# Altium schematic sheet 01-ece353_carrier.SchDoc
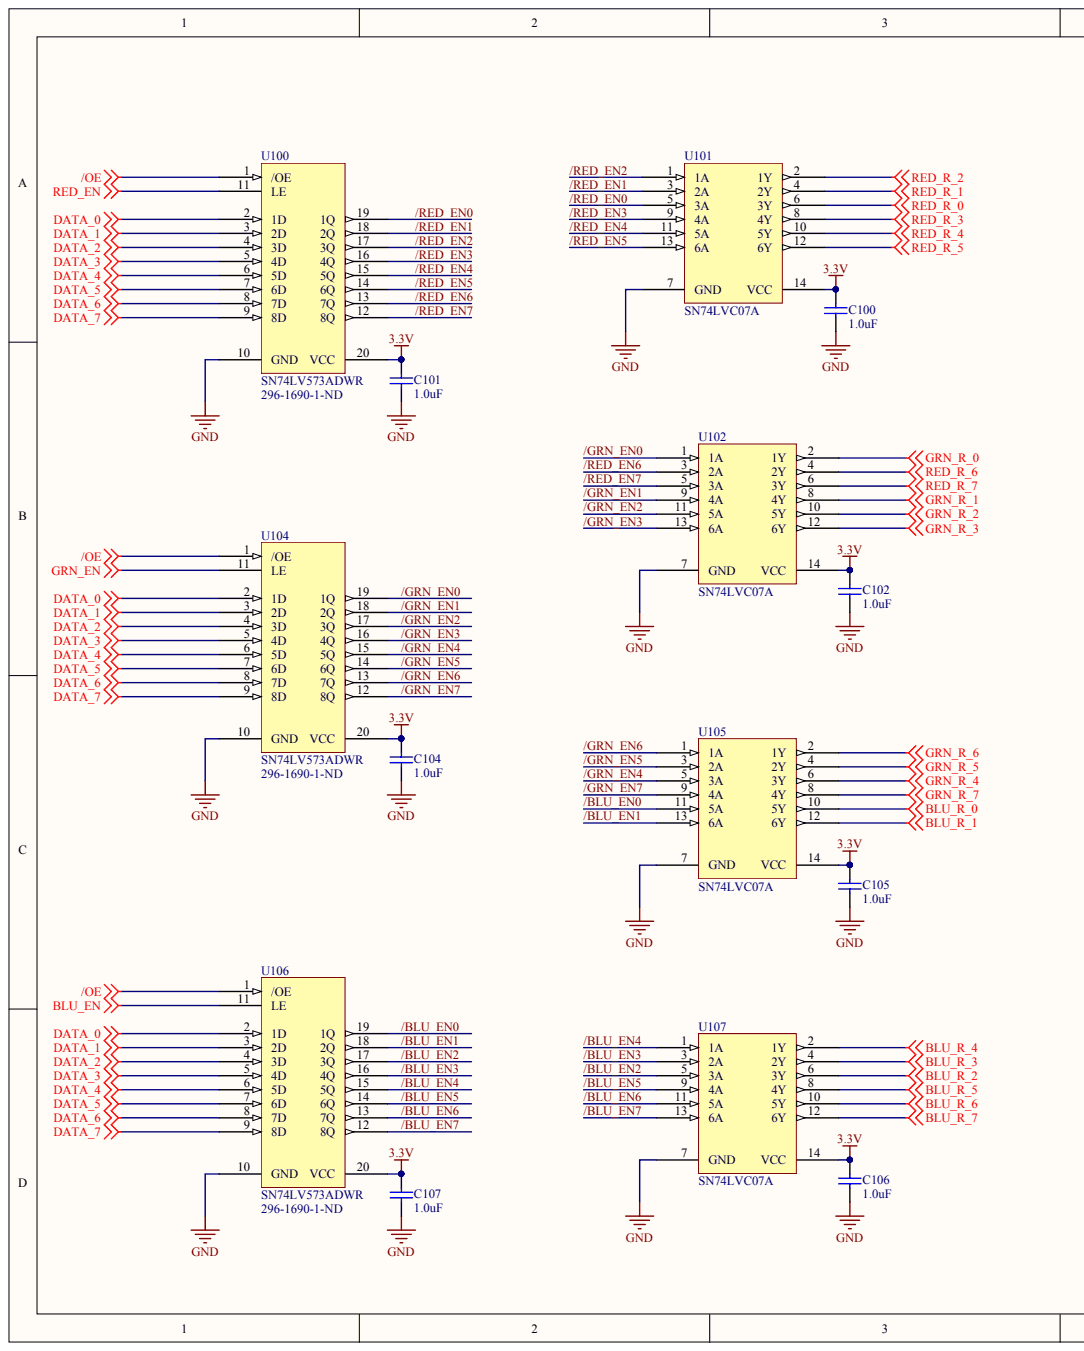
[diagram p0: 01-ece353_carrier.SchDoc, left]
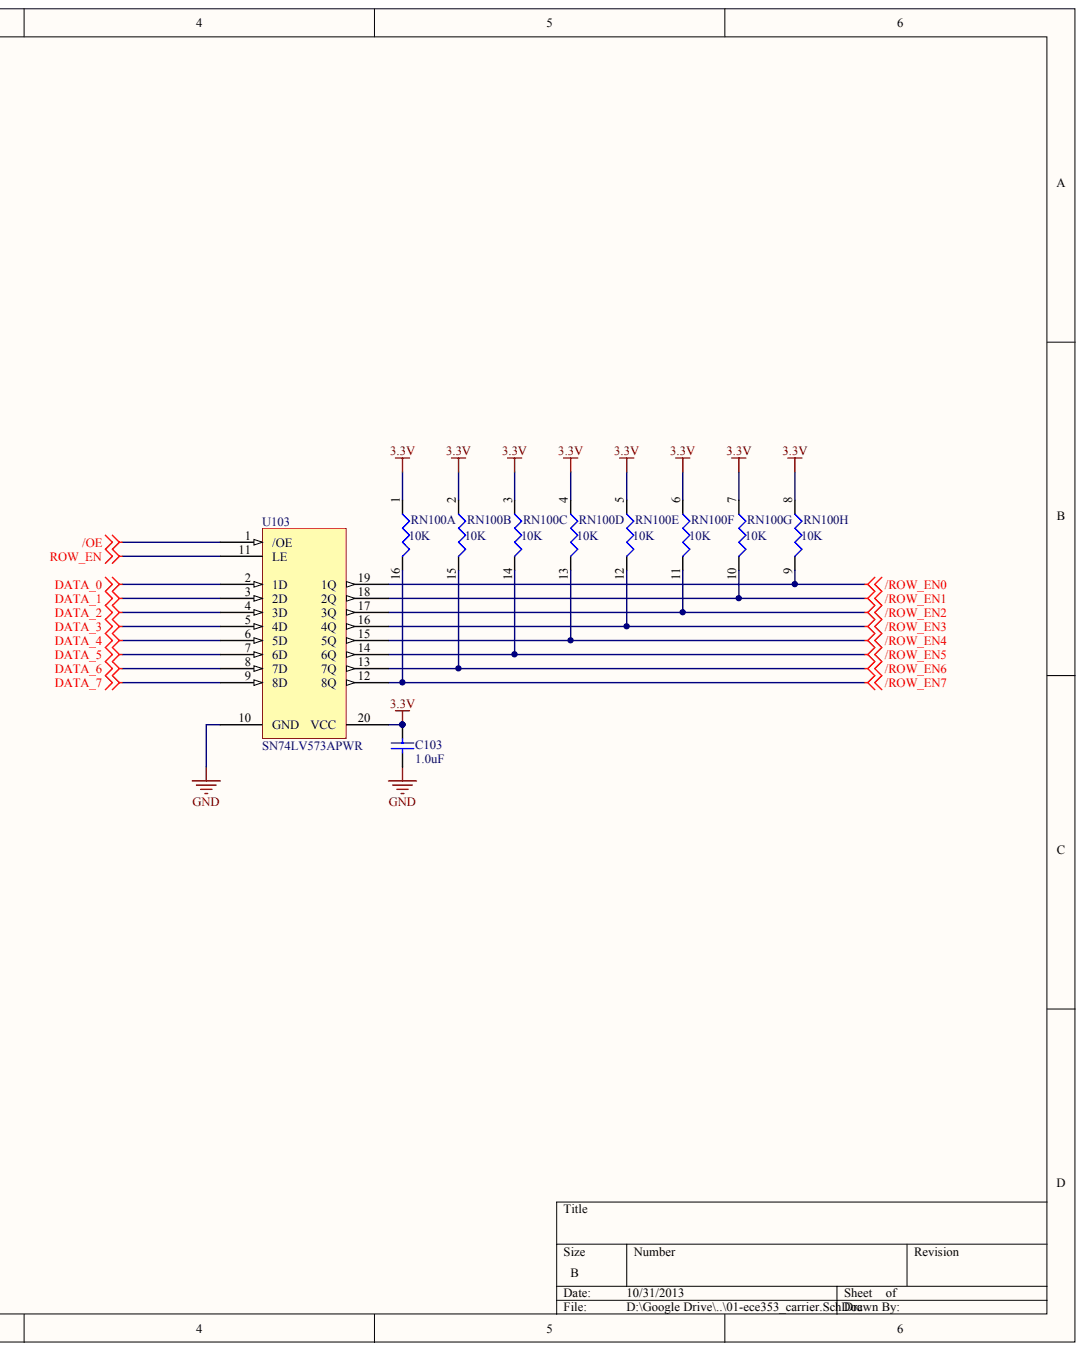
[diagram p1: 01-ece353_carrier.SchDoc, right]

## Parts
C100  value 1.0uF  (hidden comment: CAP_0805_1P00UF)
C101  value 1.0uF  (hidden comment: CAP_0805_1P00UF)
C102  value 1.0uF  (hidden comment: CAP_0805_1P00UF)
C103  value 1.0uF  (hidden comment: CAP_0805_1P00UF)
C104  value 1.0uF  (hidden comment: CAP_0805_1P00UF)
C105  value 1.0uF  (hidden comment: CAP_0805_1P00UF)
C106  value 1.0uF  (hidden comment: CAP_0805_1P00UF)
C107  value 1.0uF  (hidden comment: CAP_0805_1P00UF)
RN100  Resistance: 10K  (hidden comment: 4816P-1-103LF)  (parts A,B,C,D,E,F,G,H)
U100  SN74LV573ADWR  Supplier Part Number 1: 296-1690-1-ND
U101  SN74LVC07A
U102  SN74LVC07A
U103  SN74LV573APWR
U104  SN74LV573ADWR  Supplier Part Number 1: 296-1690-1-ND
U105  SN74LVC07A
U106  SN74LV573ADWR  Supplier Part Number 1: 296-1690-1-ND
U107  SN74LVC07A

## Nets
3.3V: C100.2 C101.2 C102.2 C103.2 C104.2 C105.2 C106.2 C107.2 RN100.1 RN100.2 RN100.3 RN100.4 RN100.5 RN100.6 RN100.7 RN100.8 U100.20(VCC) U101.14(VCC) U102.14(VCC) U103.20(VCC) U104.20(VCC) U105.14(VCC) U106.20(VCC) U107.14(VCC)
/OE: U100.1(/OE) U103.1(/OE) U104.1(/OE) U106.1(/OE)
/ROW_EN0: RN100.9 U103.19(1Q)
/ROW_EN1: RN100.10 U103.18(2Q)
/ROW_EN2: RN100.11 U103.17(3Q)
/ROW_EN3: RN100.12 U103.16(4Q)
/ROW_EN4: RN100.13 U103.15(5Q)
/ROW_EN5: RN100.14 U103.14(6Q)
/ROW_EN6: RN100.15 U103.13(7Q)
/ROW_EN7: RN100.16 U103.12(8Q)
BLU_EN: U106.11(LE)
BLU_R_0: U105.10(5Y)
BLU_R_1: U105.12(6Y)
BLU_R_2: U107.6(3Y)
BLU_R_3: U107.4(2Y)
BLU_R_4: U107.2(1Y)
BLU_R_5: U107.8(4Y)
BLU_R_6: U107.10(5Y)
BLU_R_7: U107.12(6Y)
DATA_0: U100.2(1D) U103.2(1D) U104.2(1D) U106.2(1D)
DATA_1: U100.3(2D) U103.3(2D) U104.3(2D) U106.3(2D)
DATA_2: U100.4(3D) U103.4(3D) U104.4(3D) U106.4(3D)
DATA_3: U100.5(4D) U103.5(4D) U104.5(4D) U106.5(4D)
DATA_4: U100.6(5D) U103.6(5D) U104.6(5D) U106.6(5D)
DATA_5: U100.7(6D) U103.7(6D) U104.7(6D) U106.7(6D)
DATA_6: U100.8(7D) U103.8(7D) U104.8(7D) U106.8(7D)
DATA_7: U100.9(8D) U103.9(8D) U104.9(8D) U106.9(8D)
GND: C100.1 C101.1 C102.1 C103.1 C104.1 C105.1 C106.1 C107.1 U100.10(GND) U101.7(GND) U102.7(GND) U103.10(GND) U104.10(GND) U105.7(GND) U106.10(GND) U107.7(GND)
GRN_EN: U104.11(LE)
GRN_R_0: U102.2(1Y)
GRN_R_1: U102.8(4Y)
GRN_R_2: U102.10(5Y)
GRN_R_3: U102.12(6Y)
GRN_R_4: U105.6(3Y)
GRN_R_5: U105.4(2Y)
GRN_R_6: U105.2(1Y)
GRN_R_7: U105.8(4Y)
RED_EN: U100.11(LE)
RED_R_0: U101.6(3Y)
RED_R_1: U101.4(2Y)
RED_R_2: U101.2(1Y)
RED_R_3: U101.8(4Y)
RED_R_4: U101.10(5Y)
RED_R_5: U101.12(6Y)
RED_R_6: U102.4(2Y)
RED_R_7: U102.6(3Y)
ROW_EN: U103.11(LE)
/BLU_EN0: U105.11(5A) U106.19(1Q)
/BLU_EN1: U105.13(6A) U106.18(2Q)
/BLU_EN2: U106.17(3Q) U107.5(3A)
/BLU_EN3: U106.16(4Q) U107.3(2A)
/BLU_EN4: U106.15(5Q) U107.1(1A)
/BLU_EN5: U106.14(6Q) U107.9(4A)
/BLU_EN6: U106.13(7Q) U107.11(5A)
/BLU_EN7: U106.12(8Q) U107.13(6A)
/GRN_EN0: U102.1(1A) U104.19(1Q)
/GRN_EN1: U102.9(4A) U104.18(2Q)
/GRN_EN2: U102.11(5A) U104.17(3Q)
/GRN_EN3: U102.13(6A) U104.16(4Q)
/GRN_EN4: U104.15(5Q) U105.5(3A)
/GRN_EN5: U104.14(6Q) U105.3(2A)
/GRN_EN6: U104.13(7Q) U105.1(1A)
/GRN_EN7: U104.12(8Q) U105.9(4A)
/RED_EN0: U100.19(1Q) U101.5(3A)
/RED_EN1: U100.18(2Q) U101.3(2A)
/RED_EN2: U100.17(3Q) U101.1(1A)
/RED_EN3: U100.16(4Q) U101.9(4A)
/RED_EN4: U100.15(5Q) U101.11(5A)
/RED_EN5: U100.14(6Q) U101.13(6A)
/RED_EN6: U100.13(7Q) U102.3(2A)
/RED_EN7: U100.12(8Q) U102.5(3A)

## Library data of the parts
C100-C107 RN100 U100-U107 = (no symbol name)
  C100-C107: Supplier 1: Newark; Supplier Part Number 1: 96M1389
  RN100: Supplier 1: Allied; Supplier Part Number 1: 70155467
  U100 U104 U106: Supplier 1: Digi-Key
  U101 U102 U105 U107: Supplier 1: Newark; Supplier Part Number 1: 76C4050
  U103: Supplier 1: Digi-Key; Supplier Part Number 1: 296-1690-5-ND
